# Altium schematic sheet HB_Z6.3.2_Connectors_LVPSU.SchDoc(HB_Z6.3.2_Connectors_LVPSU)
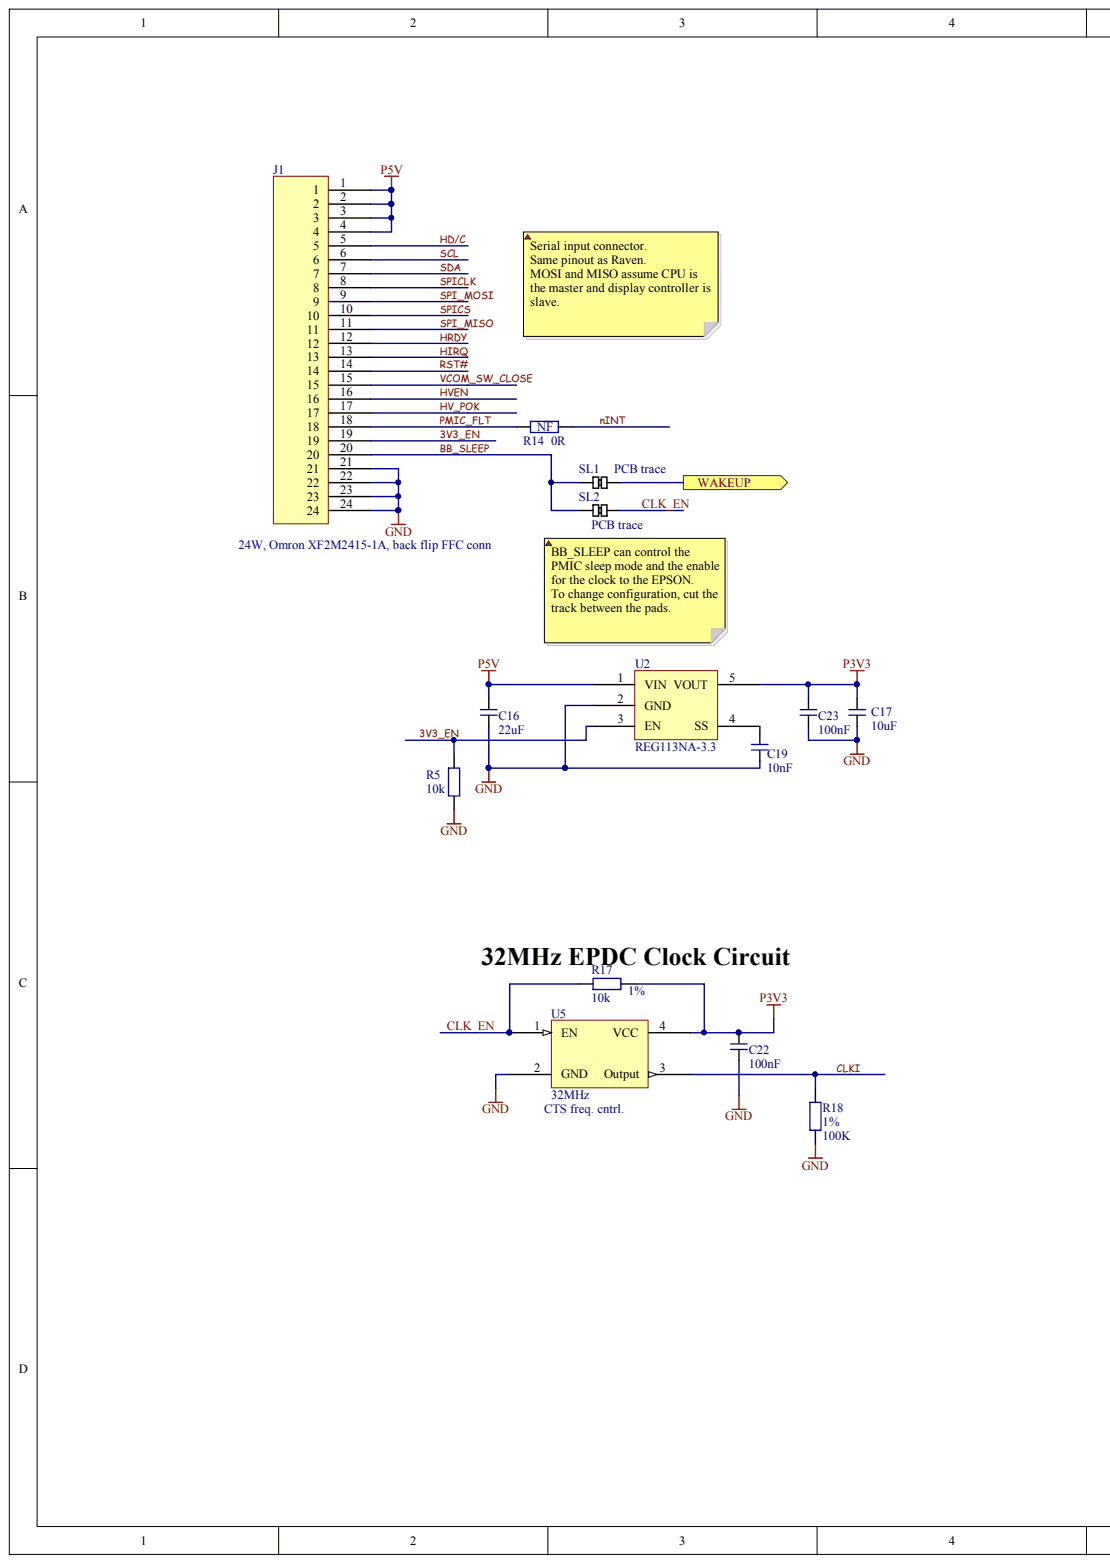
[diagram p0: HB_Z6.3.2_Connectors_LVPSU.SchDoc, left]
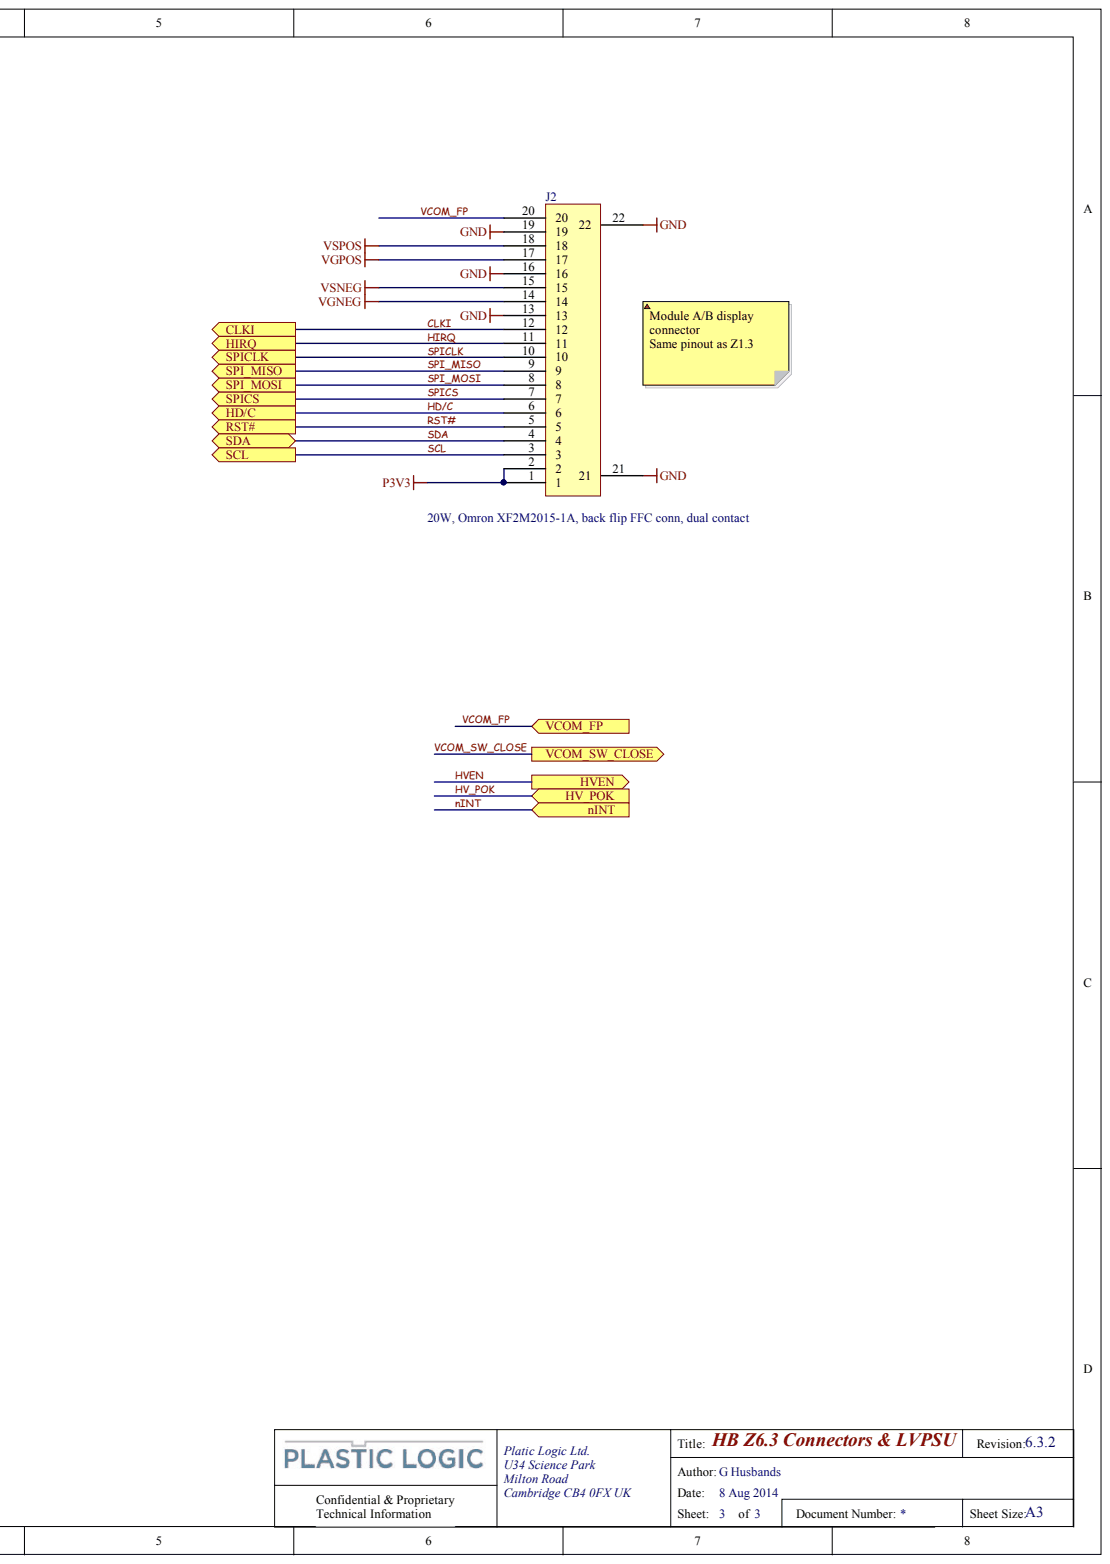
[diagram p1: HB_Z6.3.2_Connectors_LVPSU.SchDoc, right]

## Parts
C16  22uF  [C0603_small]
C17  10uF  [C0603_small]
C19  10nF  [C0402]
C22  100nF  [C0402]
C23  100nF  [C0402]
J1  24W, Omron XF2M2415-1A, back flip FFC conn  Manufacturer: Omron  [OMRON XF2M - 24W]
J2  20W, Omron XF2M2015-1A, back flip FFC conn, dual contact  Manufacturer: Omron  [OMRON XF2M - 20W]
R5  10k  [R0402]
R14  0R  Fitted: NF  [R0402]
R17  10k  Tolerance: 1%  [R0402]
R18  100K  Tolerance: 1%  [R0402]
SL1  PCB trace  [R0402 - short]
SL2  PCB trace  [R0402 - short]
U2  REG113NA-3.3  [SOT23-5]
U5  32MHz  Manufacturer: CTS freq. cntrl.  [CTS model 625 osc mod]

## Ports
CLKI, HD/C, HIRQ, HV_POK, HVEN, NINT, RST#, SCL, SDA, SPI_MISO, SPI_MOSI, SPICLK, SPICS, VCOM_FP, VCOM_SW_CLOSE, WAKEUP

## Nets
GND: C16.2 C17.1 C19.1 C22.2 C23.1 J1.21 J1.22 J1.23 J1.24 J2.13 J2.16 J2.19 J2.21 J2.22 R5.2 R18.2 U2.2(GND) U5.2(GND)
P3V3: C17.2 C22.1 C23.2 J2.1 J2.2 R17.2 U2.5(VOUT) U5.4(VCC)
P5V: C16.1 J1.1 J1.2 J1.3 J1.4 U2.1(VIN)
VGNEG: J2.14
VGPOS: J2.17
VSNEG: J2.15
VSPOS: J2.18
3V3_EN: J1.19 R5.1 U2.3(EN)
BB_SLEEP: J1.20 SL1.1 SL2.1
CLK_EN: R17.1 SL2.2 U5.1(EN)
CLKI: J2.12 R18.1 U5.3(Output) ; port CLKI
HD/C: J1.5 J2.6 ; port HD/C
HIRQ: J1.13 J2.11 ; port HIRQ
HRDY: J1.12
HV_POK: J1.17 ; port HV_POK
HVEN: J1.16 ; port HVEN
NINT: R14.1 ; port NINT
PMIC_FLT: J1.18 R14.2
RST#: J1.14 J2.5 ; port RST#
SCL: J1.6 J2.3 ; port SCL
SDA: J1.7 J2.4 ; port SDA
SPI_MISO: J1.11 J2.9 ; port SPI_MISO
SPI_MOSI: J1.9 J2.8 ; port SPI_MOSI
SPICLK: J1.8 J2.10 ; port SPICLK
SPICS: J1.10 J2.7 ; port SPICS
VCOM_FP: J2.20 ; port VCOM_FP
VCOM_SW_CLOSE: J1.15 ; port VCOM_SW_CLOSE
WAKEUP: SL1.2 ; port WAKEUP
NetC19_2: C19.2 U2.4(SS)

## Library data of the parts
C16 = ChipCap0603: 0603 Capacitor; Manufacturer: TDK; ManufacturerPN: C1608X5R0J226M080AC; Fitted: I; Material: X5R; Order Code: 445-8028-1-ND; Tolerance: 10%; Voltage: 6V3; Component Kind: Standard; Supplier: Digikey; Value: 22uF; Library Name: PlasticLogicLIB.SchLib
C17 = ChipCap0603: 0603 Capacitor; Manufacturer: AVX; ManufacturerPN: 0603ZD106MAT2A; Fitted: I; Material: X5R; Order Code: 1867954RL; Tolerance: 20%; Voltage: 10V; Component Kind: Standard; Supplier: Farnell; Value: 10uF; Library Name: PlasticLogicLIB.SchLib
C19 = ChipCap0402: 0402 Capacitor; Manufacturer: Kemet; ManufacturerPN: C0402C103K5RACTU; Fitted: I; Material: X7R; Order Code: 1414575RL; Tolerance: 10%; Voltage: 50V; Component Kind: Standard; Supplier: Farnell; Value: 10nF; Library Name: PlasticLogicLIB.SchLib
C22 C23 = ChipCap0402: 0402 Capacitor; Manufacturer: AVX; ManufacturerPN: 0402YC104KAT2A; Fitted: I; Material: X7R; Order Code: 1833861RL; Tolerance: 10%; Voltage: 16V; Component Kind: Standard; Supplier: Farnell; Value: 100nF; Library Name: PlasticLogicLIB.SchLib
J1 = FPC_CON_24P_Omron: 24Way ZIF FFC,  0.5mm pitch, Back lever, dual contact; ManufacturerPN: XF2M-2415-1A; Fitted: I; Order Code: 1112559; Component Kind: Standard; Supplier: Farnell; Value: 24W, Omron XF2M2415-1A, back flip FFC conn; Library Name: PlasticLogicLIB.SchLib
J2 = FPC_CON_20P_Omron: 20Way ZIF FFC, Omron XF2M-2015-01, 0.5mm pitch, Back lever, dual contact; ManufacturerPN: XF2M-2015-1A; Fitted: I; Order Code: 1112558; Component Kind: Standard; Supplier: Farnell; Value: 20W, Omron XF2M2015-1A, back flip FFC conn, dual contact; Library Name: PlasticLogicLIB.SchLib
R5 = ChipRes0402: 0402 Resistor; Manufacturer: VISHAY DRALORIC; ManufacturerPN: CRCW040210K0FKED; Fitted: I; Order Code: 1469669RL; Power: 0.0625W; Tolerance: 1%; Voltage: 50V; Component Kind: Standard; Material: Thick Film; Supplier: Farnell; Value: 10k; Library Name: PlasticLogicLIB.SchLib
R14 = ChipRes: Manufacturer: Yageo Phycomp; ManufacturerPN: RC0402JR-070RL; Order Code: 2131799; Power: 0.0625W; Tolerance: 1%; Voltage: 50V; Component Kind: Standard; Material: Thick Film; Supplier: Farnell; Value: 0R; Library Name: plasticlogicLIB.SchLib
R17 = ChipRes0402: 0402 Resistor; Manufacturer: VISHAY DRALORIC; ManufacturerPN: CRCW040210K0FKED; Fitted: I; Order Code: 1469669RL; Power: 0.0625W; Voltage: 50V; Component Kind: Standard; Material: Thick Film; Supplier: Farnell; Value: 10k; Library Name: PlasticLogicLIB.SchLib
R18 = ChipRes0402: 0402 Resistor; Manufacturer: WELWYN; ManufacturerPN: ASC0402-100KFT10; Fitted: I; Order Code: 2078874; Power: 0.0625W; Voltage: 50V; Component Kind: Standard; Material: Thick Film; Supplier: Farnell; Value: 100K; Library Name: PlasticLogicLIB.SchLib
SL1 SL2 = ChipRes0402_SHORT: 0402 PCB short cct link; Fitted: NF; Component Kind: Standard; Value: PCB trace; Library Name: PlasticLogicLIB.SchLib
U2 = RT9020-33PB: 3V3 LDO regulator; Manufacturer: TI; Fitted: I; Order Code: 165-2375; Component Kind: Standard; ManufacturerPN: REG113NA-3.3; Supplier: Farnell; Value: REG113NA-3.3; Library Name: PlasticLogicLIB.SchLib
U5 = Crystal osc module: Clock Oscillator; ManufacturerPN: 625L3I032M00000; Fitted: I; Order Code: CTX980CT-ND; Component Kind: Standard; Supplier: Digikey; Value: 32MHz; Library Name: PlasticLogicLIB.SchLib
